# Altium schematic sheet gps.SchDoc(gps)
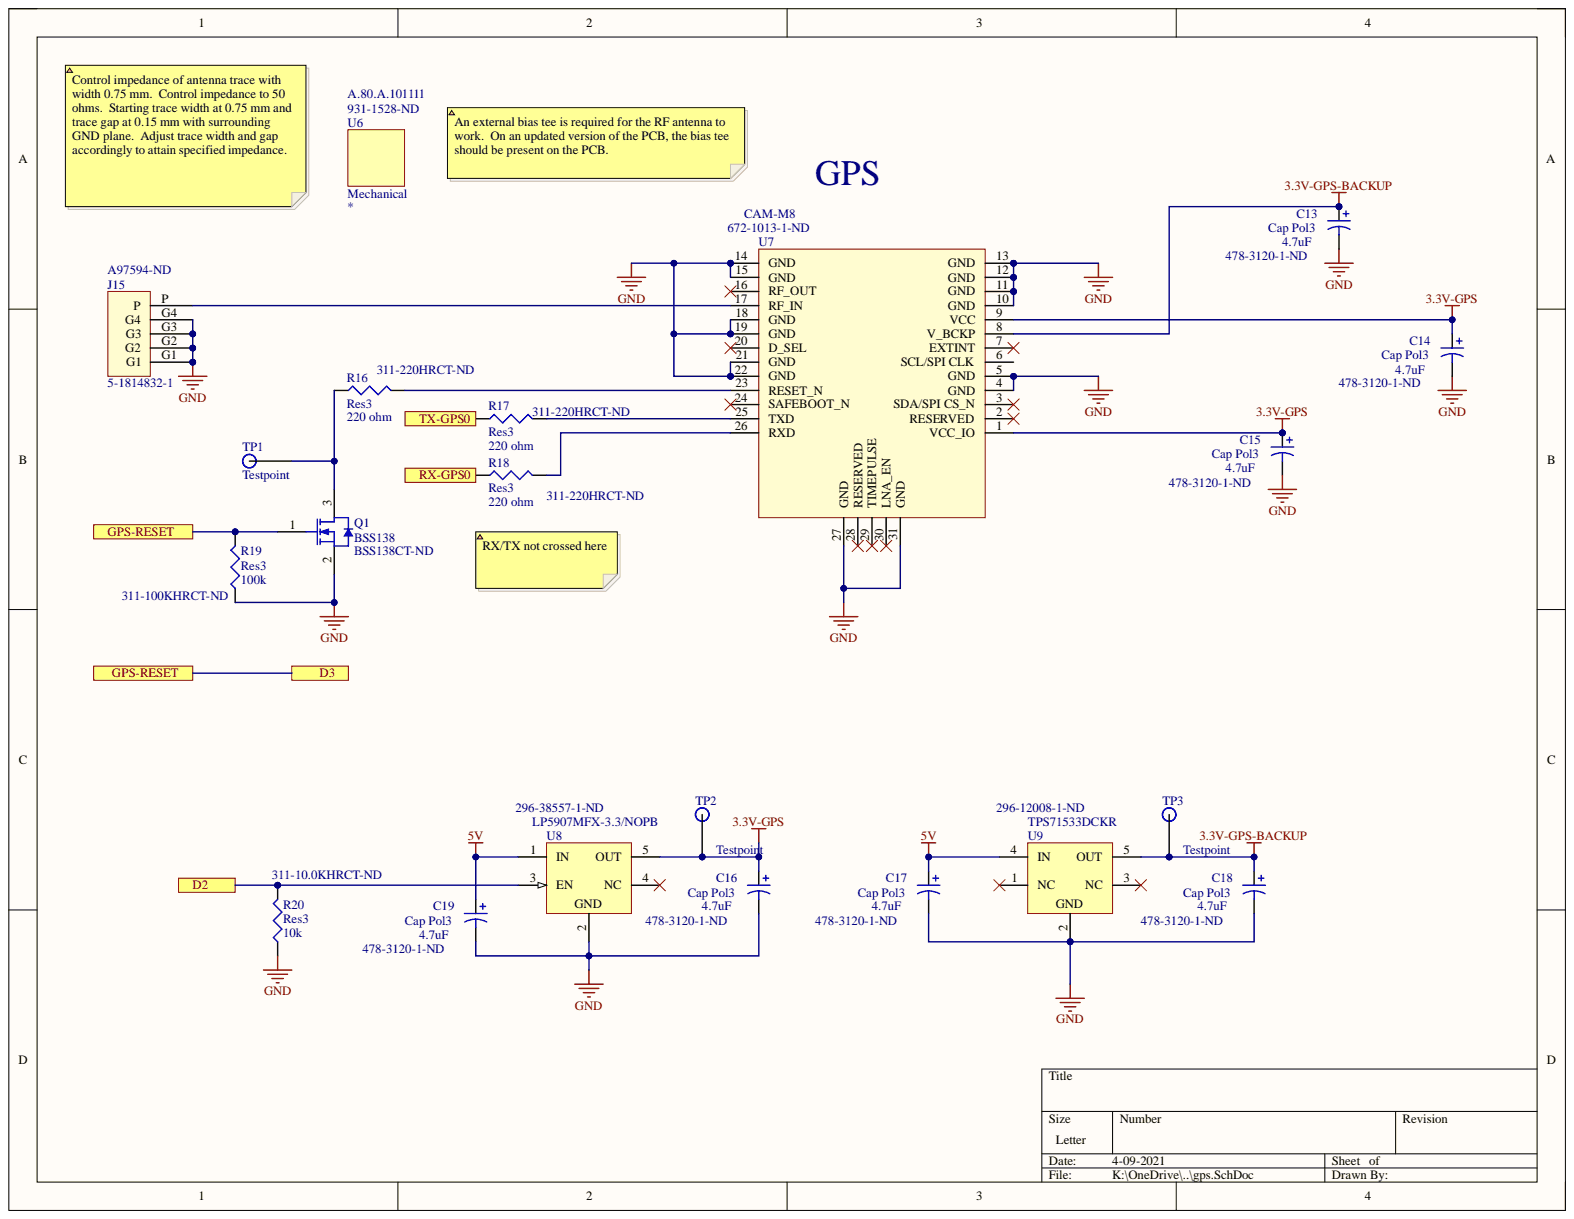
[diagram p0: gps.SchDoc]

## Parts
C13  Cap Pol3  value 4.7uF  Supplier Part Number 1: 478-3120-1-ND  [1206-pol]
C14  Cap Pol3  value 4.7uF  Supplier Part Number 1: 478-3120-1-ND  [1206-pol]
C15  Cap Pol3  value 4.7uF  Supplier Part Number 1: 478-3120-1-ND  [1206-pol]
C16  Cap Pol3  value 4.7uF  [1206-pol]
C17  Cap Pol3  value 4.7uF  Supplier Part Number 1: 478-3120-1-ND  [1206-pol]
C18  Cap Pol3  value 4.7uF  [1206-pol]
C19  Cap Pol3  value 4.7uF  Supplier Part Number 1: 478-3120-1-ND  [1206-pol]
J15  5-1814832-1  Supplier Part Number 1: A97594-ND  [5-1814832-1]
Q1  BSS138  Supplier Part Number 1: BSS138CT-ND  [FAIR-SOT23-3_V]
R16  Res3  value 220 ohm  Supplier Part Number 1: 311-220HRCT-ND  [J1-0603]
R17  Res3  value 220 ohm  Supplier Part Number 1: 311-220HRCT-ND  [J1-0603]
R18  Res3  value 220 ohm  Supplier Part Number 1: 311-220HRCT-ND  [J1-0603]
R19  Res3  value 100k  Supplier Part Number 1: 311-100KHRCT-ND  [J1-0603]
R20  Res3  value 10k  Supplier Part Number 1: 311-10.0KHRCT-ND  [J1-0603]
TP1  Testpoint  [testpoint]  (no BOM)
TP2  Testpoint  [testpoint]  (no BOM)
TP3  Testpoint  [testpoint]  (no BOM)
U6  Mechanical  Supplier Part Number 2: 931-1528-ND  (mechanical)
U7  CAM-M8  Supplier Part Number 1: 672-1013-1-ND  [CAM]
U8  LP5907MFX-3.3/NOPB  [DBV0005A_L]
U9  TPS71533DCKR  [DCK0005A_L]

## Ports
D2, D3, GPS-RESET, RX-GPS0, TX-GPS0

## Nets
3.3V-GPS: C14.1 C15.1 C16.1 TP2.1 U7.1(VCC_IO) U7.9(VCC) U8.5(OUT)
3.3V-GPS-BACKUP: C13.1 C18.1 TP3.1 U7.8(V_BCKP) U9.5(OUT)
5V: C17.1 C19.1 U8.1(IN) U9.4(IN)
GND: C13.2 C14.2 C15.2 C16.2 C17.2 C18.2 C19.2 J15.G1 J15.G2 J15.G3 J15.G4 Q1.2 R19.1 R20.1 U7.4(GND) U7.5(GND) U7.10(GND) U7.11(GND) U7.12(GND) U7.13(GND) U7.14(GND) U7.15(GND) U7.18(GND) U7.19(GND) U7.21(GND) U7.22(GND) U7.27(GND) U7.31(GND) U8.2 U9.2
D2: R20.2 U8.3(EN) ; port D2
D3: Q1.1 R19.2 ; port D3, port GPS-RESET
RX-GPS0: R18.1 ; port RX-GPS0
TX-GPS0: R17.1 ; port TX-GPS0
NetJ15_P: J15.P U7.17(RF_IN)
NetQ1_3: Q1.3 R16.1 TP1.1
NetR16_2: R16.2 U7.23(RESET_N)
NetR17_2: R17.2 U7.25(TXD)
NetR18_2: R18.2 U7.26(RXD)

Not connected: U7 2(RESERVED) 3(SDA/SPI_CS_N) 6(SCL/SPI_CLK) 7(EXTINT) 16(RF_OUT) 20(D_SEL) 24(SAFEBOOT_N) 28(RESERVED) 29(TIMEPULSE) 30(LNA_EN); U8 4(NC); U9 1(NC) 3(NC)

## Library data of the parts
C13-C19 = Cap Pol3: Polarized Capacitor (Surface Mount); Manufacturer: AVX Corporation; Manufacturer Part Number: NOJA475M010RWJ; Manufacturer Size Code: A; Dissipation Factor: 6%; Tolerance: ±20%; Category: Capacitors; Component Kind: Standard; ComponentLink1Description: http://datasheets.avx.com/NOJ.pdf; ComponentLink1URL: http://datasheets.avx.com/NOJ.pdf; Features: -; Height - Seated (Max): 0.071" (1.80mm); Library Name: Miscellaneous Devices.IntLib; Mounting Type: Surface Mount; Operating Temperature: -55°C ~ 105°C; Package / Case: 1206 (3216 Metric); Packaging: Cut Tape (CT); Part Status: Active; Pricing 1: 1=0.68, 10=0.485, 100=0.319, 500=0.2363, 1000=0.20086 (CAD); RoHS: RoHS Compliant; Signal Integrity: Cap Pol; Simulation: CAP; Size / Dimension: 0.126" L x 0.063" W (3.20mm x 1.60mm); Stock 1: 5356; Supplier 1: Digi-Key; Supplier Device Package: 1206 (3216 Metric)
  C16 C18: Supplier Part Number 1: 478-3120-1-ND
J15 = 5-1814832-1: Manufacturer: TE Connectivity; Body Material: Zinc; Body Plating: Gold; Connector System: Cable-to-Board; Connector Type: SMA; Dielectric Material: PTFE; Frequency: 3GHz; Gender: Female; Impedance: 50R; Insulation Resistance: 5GR; Number of Positions: 1; Plating: Nickel; Polarity: Normal; Sealable: No (Without); Tail Length: 4mm; Wire/Cable Type: Coaxial; Case/Package: SMA; Component Kind: Standard; ComponentLink1Description: https://datasheet.ciiva.com/642/eng-cd-1814832-b1-642785.pdf?src-supplier=Digi-Key; ComponentLink1URL: https://datasheet.ciiva.com/642/eng-cd-1814832-b1-642785.pdf?src-supplier=Digi-Key; ComponentLink2Description: https://datasheet.ciiva.com/642/eng-cd-1814832-b1-642785.pdf?src-supplier=Avnet; ComponentLink2URL: https://datasheet.ciiva.com/642/eng-cd-1814832-b1-642785.pdf?src-supplier=Avnet; ComponentLink3Description: https://datasheet.ciiva.com/23783/1663582-23783560.pdf?src-supplier=Newark; ComponentLink3URL: https://datasheet.ciiva.com/23783/1663582-23783560.pdf?src-supplier=Newark; ComponentLink4Description: https://datasheet.ciiva.com/16318/5-1814832-1-16318587.pdf?src-supplier=Future+Electronics; ComponentLink4URL: https://datasheet.ciiva.com/16318/5-1814832-1-16318587.pdf?src-supplier=Future+Electronics; ComponentLink5Description: https://datasheet.ciiva.com/11762/0900766b80a70d0f-11762254.pdf?src-supplier=RSComponents; ComponentLink5URL: https://datasheet.ciiva.com/11762/0900766b80a70d0f-11762254.pdf?src-supplier=RSComponents; ComponentLink6Description: https://datasheet.ciiva.com/26824/getdatasheetpartid-396696-26824822.pdf?src-supplier=Verical; ComponentLink6URL: https://datasheet.ciiva.com/26824/getdatasheetpartid-396696-26824822.pdf?src-supplier=Verical; ComponentLink7Description: https://datasheet.ciiva.com/30201/eng-cd-1814832-a-30201302.pdf?src-supplier=Onlinecomponents.com; ComponentLink7URL: https://datasheet.ciiva.com/30201/eng-cd-1814832-a-30201302.pdf?src-supplier=Onlinecomponents.com; ComponentLink8Description: https://datasheet.ciiva.com/25908/eng-cd-1814832-a-25908583.pdf?src-supplier=Avnet+Europe; ComponentLink8URL: https://datasheet.ciiva.com/25908/eng-cd-1814832-a-25908583.pdf?src-supplier=Avnet+Europe; ComponentLink9Description: https://datasheet.ciiva.com/16469/eng-cd-1814832-a-16469256.pdf?src-supplier=Avnet+Asia; ComponentLink9URL: https://datasheet.ciiva.com/16469/eng-cd-1814832-a-16469256.pdf?src-supplier=Avnet+Asia; Contact Material: Bronze; Contact Plating: Gold; Depth: 6.4mm; Description: 5-1814832-1; ELV: Compliant; Fastening Type: Threaded; Insulation Material: Polytetrafluoroethylene; Lead Free: Lead Free; Length: 0.532inch; Library Name: TE.IntLib; Manufacturer Part Number: 5-1814832-1; Max Frequency: 3GHz; Max Operating Temperature: 185°C; Min Operating Temperature: -67°C; Mount: Through Hole; Orientation: Straight; Package Quantity: 1; Packaging: Box; Pricing 1: 1 = 1.99000, 10 = 1.80400, 25 = 1.69360, 50 = 1.62000, 100 = 1.54640, 250 = 1.39908, 500 = 1.28864, 1000 = 1.10454 (USD); Radiation Hardening: No; REACH SVHC: No SVHC; RoHS: Yes; Stock 1: 34232; Supplier 1: Digi-Key; Termination: Through Hole
Q1 = CMP-1670-00009-1: N-Channel Logic Level Enhancement Mode Field Effect Transistor, 220 mA, 50 V, -55 to 150 degC, 3-Pin SOT-23, RoHS, Tape and Reel; Manufacturer: Fairchild/ON Semiconductor; Capacitance: 1.8E-11 f; Current - Continuous Drain (Id) @ 25°C: 220mA (Ta); Drain to Source Voltage (Vdss): 50V; Drive Voltage (Max Rds On,  Min Rds On): 4.5V, 10V; ESR: 40 Ohm; Frequency: 12 MHz; Frequency Tolerance: 30ppm; Gate Charge (Qg) (Max) @ Vgs: 2.4nC @ 10V; Input Capacitance (Ciss) (Max) @ Vds: 27pF @ 25V; Load Capacitance: 18 pF; Power Dissipation (Max): 360mW (Ta); Rds On (Max) @ Id, Vgs: 3.5 Ohm @ 220mA, 10V; Resistance: 100 Ohm; Vgs (Max): ±20V; Vgs(th) (Max) @ Id: 1.5V @ 1mA; Category: Discrete Semiconductor Products; Component Kind: Standard; ComponentLink1Description: Manufacturer URL; ComponentLink1URL: https://www.fairchildsemi.com/; ComponentLink2Description: Datasheet; ComponentLink2URL: https://www.fairchildsemi.com/datasheets/BS/BSS138.pdf; ComponentLink3Description: Package Specification; ComponentLink3URL: http://www.fairchildsemi.com/dwg/MA/MA03D.pdf; DatasheetVersion: Rev. C, 10/2005; FET Feature: -; FET Type: N-Channel; Height: 0.041 inch; Length: 0.197 inch; Library Name: Altium Content Vault; Manufacturer Part Number: BSS138; Max Operating Temperature: 70degC; Min Operating Temperature: -20degC; Mount: Surface Mount; Mounting Type: Surface Mount; Operating Temperature: -55°C ~ 150°C (TJ); Package / Case: TO-236-3, SC-59, SOT-23-3; Package Quantity: 1; PackageDescription: 3-Pin Small Outline Transistor, Body 2.92 x 1.325 mm, Pitch 0.95 mm; PackageReference: SOT23-3; Packaging: Tape and Reel; Part Status: Active; Pins: 4; Pricing 1: 1=0.31, 10=0.252, 100=0.1339, 500=0.0881, 1000=0.05991 (CAD); REACH SVHC: Unknown; RoHS: RoHS Compliant; RoHSCompliant: True; Stock 1: 450063; Supplier 1: Digi-Key; Supplier Device Package: SOT-23-3; Technology: MOSFET (Metal Oxide); Tolerance: 30ppm; Width: 0.126 inch
R16 R18 = Res3: Resistor; Manufacturer: Yageo; Manufacturer Part Number: RC0603FR-07220RL; Power (Watts): 0.1W, 1/10W; Temperature Coefficient: ±100ppm/°C; Tolerance: ±1%; Category: Resistors; Component Kind: Standard; ComponentLink1Description: http://www.yageo.com/documents/recent/PYu-RC_Group_51_RoHS_L_7.pdf; ComponentLink1URL: http://www.yageo.com/documents/recent/PYu-RC_Group_51_RoHS_L_7.pdf; Composition: Thick Film; Failure Rate: -; Features: Moisture Resistant; Height - Seated (Max): 0.022" (0.55mm); LatestRevisionDate: 29-May-2009; LatestRevisionNote: IPC-7351 Footprint Added.; Library Name: Miscellaneous Devices.IntLib; Operating Temperature: -55°C ~ 155°C; Package / Case: 0603 (1608 Metric); PackageDescription: Chip Resistor; PackageReference: J1-0603; Packaging: Cut Tape (CT); Part Status: Active; Pricing 1: 1=0.14, 10=0.021, 100=0.0086, 1000=0.00383, 2500=0.00333 (CAD); Published: 8-Jun-2000; RoHS: RoHS Compliant; Signal Integrity: Res; Simulation: RESISTOR; Size / Dimension: 0.063" L x 0.032" W (1.60mm x 0.80mm); Stock 1: 1006774; Supplier 1: Digi-Key; Supplier Device Package: 0603; Publisher: Altium Limited
R17 = Res3: Resistor; Manufacturer: Yageo; Manufacturer Part Number: RC0603FR-07220RL; Power (Watts): 0.1W, 1/10W; Temperature Coefficient: ±100ppm/°C; Tolerance: ±1%; Value: 220 ohm; Category: Resistors; Component Kind: Standard; ComponentLink1Description: http://www.yageo.com/documents/recent/PYu-RC_Group_51_RoHS_L_7.pdf; ComponentLink1URL: http://www.yageo.com/documents/recent/PYu-RC_Group_51_RoHS_L_7.pdf; Composition: Thick Film; Failure Rate: -; Features: Moisture Resistant; Height - Seated (Max): 0.022" (0.55mm); LatestRevisionDate: 29-May-2009; LatestRevisionNote: IPC-7351 Footprint Added.; Library Name: Miscellaneous Devices.IntLib; Operating Temperature: -55°C ~ 155°C; Package / Case: 0603 (1608 Metric); PackageDescription: Chip Resistor; PackageReference: J1-0603; Packaging: Cut Tape (CT); Part Status: Active; Pricing 1: 1=0.14, 10=0.021, 100=0.0086, 1000=0.00383, 2500=0.00333 (CAD); Published: 8-Jun-2000; RoHS: RoHS Compliant; Signal Integrity: Res; Simulation: RESISTOR; Size / Dimension: 0.063" L x 0.032" W (1.60mm x 0.80mm); Stock 1: 1006774; Supplier 1: Digi-Key; Supplier Device Package: 0603; Publisher: Altium Limited
R19 = Res3: Resistor; Manufacturer: Yageo; Manufacturer Part Number: RC0603FR-07100KL; Power (Watts): 0.1W, 1/10W; Temperature Coefficient: ±100ppm/°C; Tolerance: ±1%; Category: Resistors; Component Kind: Standard; ComponentLink1Description: http://www.yageo.com/documents/recent/PYu-RC_Group_51_RoHS_L_7.pdf; ComponentLink1URL: http://www.yageo.com/documents/recent/PYu-RC_Group_51_RoHS_L_7.pdf; Composition: Thick Film; Failure Rate: -; Features: Moisture Resistant; Height - Seated (Max): 0.022" (0.55mm); LatestRevisionDate: 29-May-2009; LatestRevisionNote: IPC-7351 Footprint Added.; Library Name: Miscellaneous Devices.IntLib; Operating Temperature: -55°C ~ 155°C; Package / Case: 0603 (1608 Metric); PackageDescription: Chip Resistor; PackageReference: J1-0603; Packaging: Cut Tape (CT); Part Status: Active; Pricing 1: 1=0.14, 10=0.021, 100=0.0086, 1000=0.00383, 2500=0.00333 (CAD); Published: 8-Jun-2000; RoHS: RoHS Compliant; Signal Integrity: Res; Simulation: RESISTOR; Size / Dimension: 0.063" L x 0.032" W (1.60mm x 0.80mm); Stock 1: 8279421; Supplier 1: Digi-Key; Supplier Device Package: 0603; Publisher: Altium Limited
R20 = Res3: Resistor; Manufacturer: Yageo; Manufacturer Part Number: RC0603FR-0710KL; Power Rating: 100mW; Resistance: 10kR; Temperature Coefficient: 100ppm/°C; Tolerance: 1%; Voltage Rating: 75V; Voltage Rating (DC): 50V; Case Code (Imperial): 0603; Case Code (Metric): 1608; Case/Package: 0603; Component Kind: Standard; ComponentLink1Description: https://datasheet.ciiva.com/7660/38590-7660789.pdf?src-supplier=Element14; ComponentLink1URL: https://datasheet.ciiva.com/7660/38590-7660789.pdf?src-supplier=Element14; ComponentLink2Description: https://datasheet.ciiva.com/15189/getdatasheetpartid-409289-15189591.pdf?src-supplier=Verical; ComponentLink2URL: https://datasheet.ciiva.com/15189/getdatasheetpartid-409289-15189591.pdf?src-supplier=Verical; ComponentLink3Description: https://datasheet.ciiva.com/46440/1868787-46440891.pdf?src-supplier=Element14; ComponentLink3URL: https://datasheet.ciiva.com/46440/1868787-46440891.pdf?src-supplier=Element14; ComponentLink4Description: https://datasheet.ciiva.com/7983/443971-7983596.pdf?src-supplier=Element14; ComponentLink4URL: https://datasheet.ciiva.com/7983/443971-7983596.pdf?src-supplier=Element14; ComponentLink5Description: https://datasheet.ciiva.com/7746/1738695-7746239.pdf?src-supplier=Element14; ComponentLink5URL: https://datasheet.ciiva.com/7746/1738695-7746239.pdf?src-supplier=Element14; ComponentLink6Description: https://datasheet.ciiva.com/22747/443971-22747519.pdf?src-supplier=Element14; ComponentLink6URL: https://datasheet.ciiva.com/22747/443971-22747519.pdf?src-supplier=Element14; Composition: Thick Film; Depth: 0.8mm; Height: 0.45mm; LatestRevisionDate: 29-May-2009; LatestRevisionNote: IPC-7351 Footprint Added.; Lead Free: Lead Free; Lead Pitch: 0.3mm; Length: 1.6mm; Library Name: Miscellaneous Devices.IntLib; Max Operating Temperature: 155°C; Military Standard: Not; Min Operating Temperature: -55°C; Number of Pins: 2; PackageDescription: Chip Resistor; PackageReference: J1-0603; Packaging: Tape and Reel; Pricing 1: 1 = 0.10000, 10 = 0.02400, 100 = 0.00970, 1000 = 0.00437, 2500 = 0.00379 (USD); Published: 8-Jun-2000; REACH SVHC: No SVHC; RoHS: Yes; Signal Integrity: Res; Simulation: RESISTOR; Stock 1: 90146690; Supplier 1: Digi-Key; Termination: SMD/SMT; Weight: 7.1E-05oz; Width: 0.8mm; Publisher: Altium Limited
TP1-TP3 = SMT Testpoint: Description: SMT Testpoint; Library Name: testpoints.IntLib
U6 = Mechanical part: Manufacturer: Taoglas; Manufacturer Part Number: A.80.A.101111; Description: Mechanical Part; Library Name: mechanical.IntLib; Pricing 2: 1 = 97.25000, 10 = 91.17000, 25 = 86.91600, 100 = 82.05340 (USD); Stock 2: 12; Supplier 2: Digi-Key
U7 = CAM-M8: u-blox CAM GNSS antenna module; Manufacturer Part Number: CAM-M8Q-0; Antenna Connector: On-Board, Chip; Current - Receiving: 29mA; Data Interface: SPI, UART; Frequency: 1.575GHz; Modulation or Protocol: BeiDou, GLONASS, GNSS, GPS; Sensitivity: -167dBm; Voltage - Supply: 1.6 V ~ 3.6 V; Applications: General Purpose; Category: RF/IF and RFID; Component Kind: Standard; ComponentLink1Description: https://www.u-blox.com/sites/default/files/CAM-M8-FW3_DataSheet_(UBX-15031574).pdf; ComponentLink1URL: https://www.u-blox.com/sites/default/files/CAM-M8-FW3_DataSheet_(UBX-15031574).pdf; Data Rate (Max): -; Features: -; Library Name: ubloxLib.IntLib; Manufacturer: u-blox; Memory Size: -; Operating Temperature: -40°C ~ 85°C; Package / Case: 31-SMD Module; Packaging: Cut Tape (CT); Part Description: u-blox CAM GNSS antenna module; Part Status: Active; Pricing 1: 1=47.18, 10=40.627, 50=26.4008, 100=18.256 (CAD); RoHS: RoHS Compliant; Stock 1: 1338; Supplier 1: Digi-Key; Supplier Device Package: Module; Value: CAM-M8
U8 = CMP-2000-07464-1: Ultra-Low-Noise, Low-IQ LDO, 0.25 A, Fixed 3.3 V Output, 2.2 to 5.5 V Input, -40 to 125 degC, 5-Pin SOT-23 (DBV), Green (RoHS & no Sb/Br), Tape and Reel; Manufacturer: Texas Instruments; Accuracy: 2%; Dropout Voltage: 250mV; Max Input Voltage: 5.5V; Max Output Current: 250mA; Min Input Voltage: 2.2V; Nominal Output Voltage: 3.3V; Number of Outputs: 1; Package Version: 4073253-4/N, 12/2014; Polarity: Positive; Power Supply Rejection Ratio (PSRR): 82dB; Quiescent Current: 425uA; Case/Package: SOT-23; Case\/Package: SOT-23; Component Kind: Standard; ComponentLink1Description: https://datasheet.ciiva.com/15083/lp5907-15083592.pdf?src-supplier=Digi-Key; ComponentLink1URL: https://datasheet.ciiva.com/15083/lp5907-15083592.pdf?src-supplier=Digi-Key; ComponentLink2Description: https://datasheet.ciiva.com/48475/lp5907-48475084.pdf?src-supplier=Avnet; ComponentLink2URL: https://datasheet.ciiva.com/48475/lp5907-48475084.pdf?src-supplier=Avnet; Contact Plating: Tin; Datasheet URL: http://www.ti.com/lit/ds/symlink/lp5907.pdf; Datasheet Version: Rev. L, 09/2016; Lead Free: LeadFree; Library Name: Altium Content Vault; Manufacturer Part Number: LP5907MFX-3.3/NOPB; Manufacturer URL: http://www.ti.com/; Max Operating Temperature: 125degC; Min Operating Temperature: -40degC; Mount: SurfaceMount; Mounting Technology: SurfaceMount; Number of Pins: 5; Number of Regulators: 1; Output Current: 250mA; Output Type: Fixed; Output Voltage: 3.3V; Output Voltage Accuracy: 2%; Package Description: 5-Pin Small Outline Transistor, Body 2.9 x 1.6 mm, Pitch 0.95 mm; Package Quantity: 3000; Package Reference: DBV5; Package URL: http://www.ti.com/lit/ml/mpds018l/mpds018l.pdf; Packaging: TapeandReel; Pricing 1: 1 = 0.55000, 10 = 0.47500, 100 = 0.35470, 500 = 0.27872, 1000 = 0.21537 (USD); Radiation Hardening: No; REACH SVHC: NoSVHC; RoHS: Yes; RoHSCompliant: true; Stock 1: 414376; Supplier 1: Digi-Key; Supplier Part Number 1: 296-38557-1-ND
U9 = CMP-0394-00925-2: Single Output LDO, 50 mA, Fixed 3.3 V Output, 3 to 24 V Input, 5-pin SC70 (DCK), -40 to 85 degC, Green (RoHS & no Sb/Br); Manufacturer: Texas Instruments; Accuracy: 4%; Accuracy(%): 4; Current Rating: 50mA; Dropout Voltage: 415mV; Fixed Output Options(V): 3.3; Input Bias Current: 3.2uA; Iout(Max)(A): 0.05; Iq(Typ)(mA): 0.003; Max Input Voltage: 24V; Max Output Voltage: 3.3V; Max Power Dissipation: 320mW; Min Current Limit: 125mA; Min Input Voltage: 3V; Min Supply Voltage: 2.5V; Number of Outputs: 1; Polarity: Positive; Quiescent Current: 3uA; SubFamily: Single Channel LDO; Vdo(Typ)(mV): 415; Vin(Max)(V): 24; Vin(Min)(V): 3; Case/Package: SC; Code_JEDEC: MO-203; Component Kind: Standard; ComponentLink1Description: Manufacturer URL; ComponentLink1URL: http://www.ti.com/; ComponentLink2Description: Datasheet; ComponentLink2URL: http://www.ti.com/general/docs/lit/getliterature.tsp?genericPartNumber=TPS71533&fileType=pdf; ComponentLink3Description: Package Specification; ComponentLink3URL: http://www.ti.com/litv/pdf/mpds025f; ComponentLink4Description: https://datasheet.ciiva.com/22700/1835023-22700176.pdf?src-supplier=Element14; ComponentLink4URL: https://datasheet.ciiva.com/22700/1835023-22700176.pdf?src-supplier=Element14; ComponentLink5Description: https://datasheet.ciiva.com/27051/1116751-27051464.pdf?src-supplier=Jameco; ComponentLink5URL: https://datasheet.ciiva.com/27051/1116751-27051464.pdf?src-supplier=Jameco; ComponentLink6Description: https://datasheet.ciiva.com/7992/1854688-7992972.pdf?src-supplier=Element14; ComponentLink6URL: https://datasheet.ciiva.com/7992/1854688-7992972.pdf?src-supplier=Element14; DatasheetVersion: SLVS338P; Eco-Plan: Green (RoHS & no Sb/Br); Height: 0.9mm; Lead Free: Lead Free; Length: 2mm; Library Name: Altium Content Vault; Manufacturer Part Number: TPS71533DCKR; Max Operating Temperature: 125°C; Max Output Current: 50mA; Min Operating Temperature: -40°C; Min Output Voltage: 3.3V; Mounting Technology: Surface Mount; Nominal Output Voltage: 3.3V; Number of Pins: 5; Number of Regulators: 1; Output Current: 50mA; Output Type: Fixed; Output Voltage: 3.3V; Output Voltage Accuracy: 4%; Package Quantity: 3000; PackageDescription: 5-Pin Plastic Small-Outline, Body 2 x 1.25 mm, Pitch 0.65 mm; PackageReference: DCK0005A; PackageVersion: 4093553-3/G; Packaging: Tape and Reel; PartNumber: TPS71533DCKR; Power Dissipation: 320mW; Power Rating: 320mW; Pricing 1: 1 = 0.90000, 10 = 0.80200, 100 = 0.62540, 500 = 0.51664, 1000 = 0.40788 (USD); Radiation Hardening: No; REACH SVHC: No SVHC; RoHS: Yes; Stock 1: 159612; Supplier 1: Digi-Key; Supplier Part Number 1: 296-12008-1-ND; Termination: SMD/SMT; Vout Adj(Max)(V): 3.3; Vout Adj(Min)(V): 3.3; Width: 1.25mm
